# Altium schematic sheet Buzzer.SchDoc
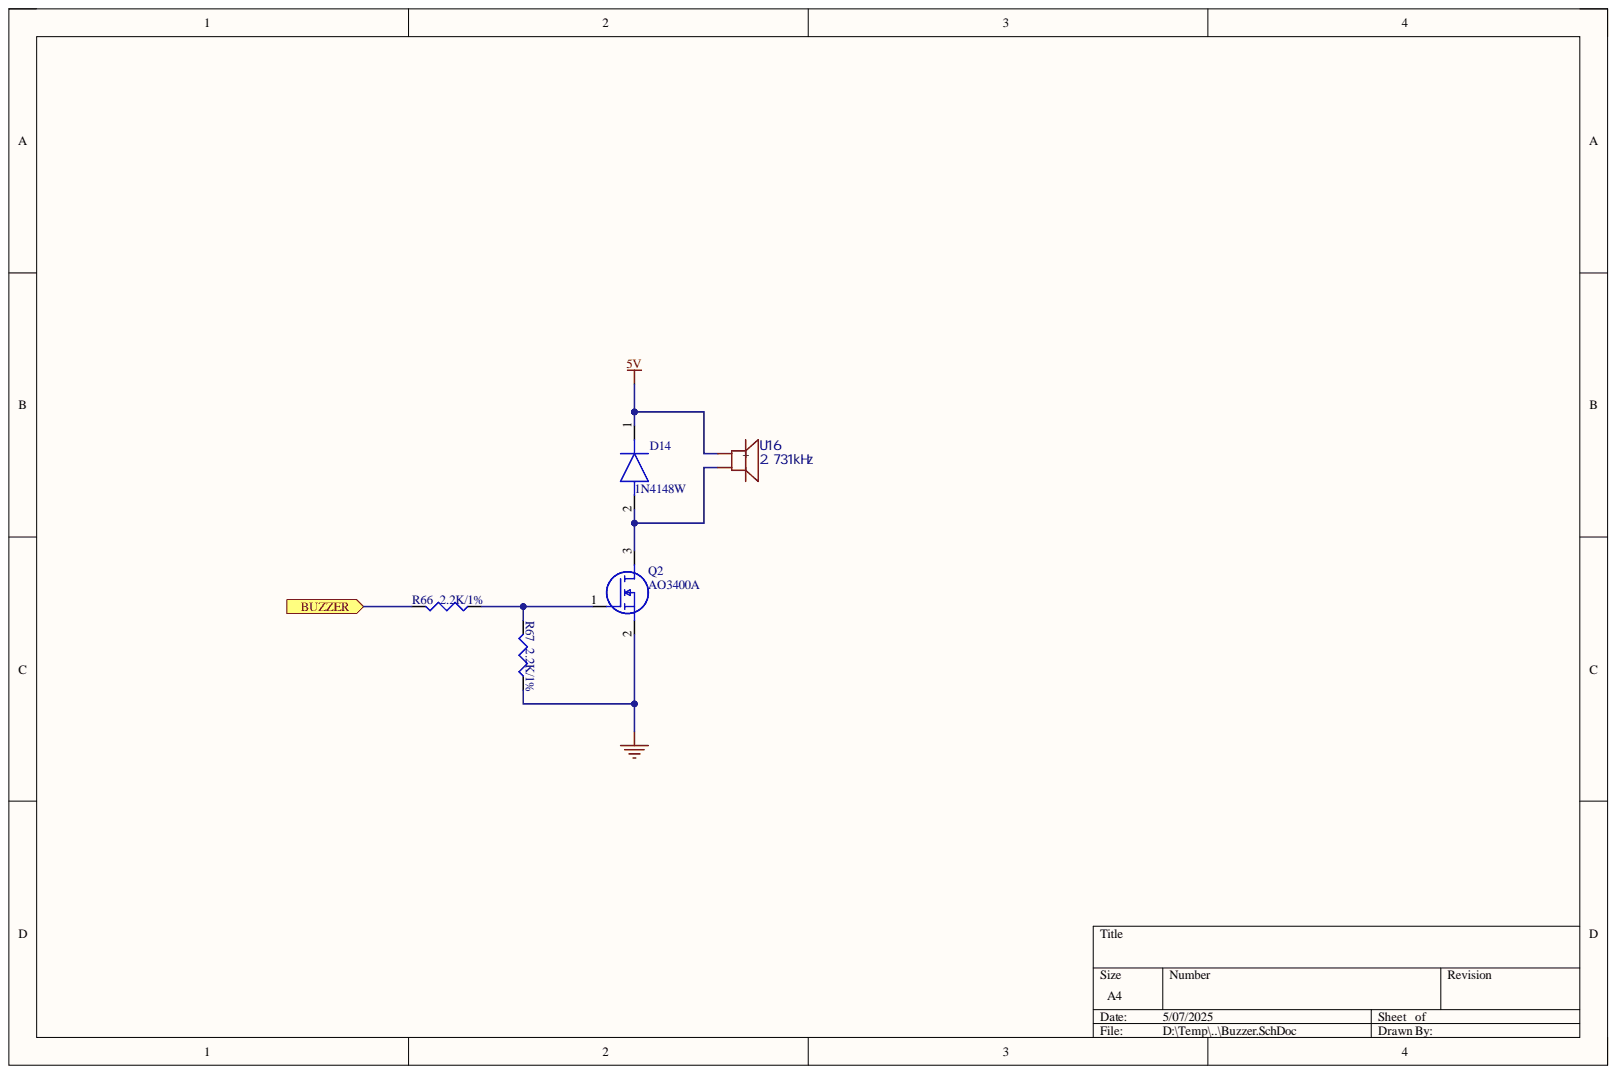
[diagram p0: Buzzer.SchDoc]

## Parts
D14  1N4148W  [1N4148WVGS08]
Q2  AO3400A  [SOT95P280X125-3N]
R66  2.2K/1%  [R0402]
R67  2.2K/1%  [R0402]
U16  2.731kHz  [BUZ-SMD_4P-L7.5-W7.5-BR]

## Ports
BUZZER

## Nets
5V: D14.1 U16.1
GND: Q2.2 R67.1
BUZZER: R66.2 ; port BUZZER
NetD14_2: D14.2 Q2.3 U16.2
NetQ2_1: Q2.1 R66.1 R67.2
NetU16_3: U16.3
NetU16_4: U16.4(+)

## Library data of the parts
D14 = 1N4148W-V-GS08: Diode; Manufacturer_Name: Vishay; Arrow Part Number: 1N4148W-V-GS08; Arrow Price/Stock: https://www.arrow.com/en/products/1n4148w-v-gs08/vishay; Component Kind: Standard; Datasheet Link: https://us.rs-online.com/m/d/a2789c8794cb0c42ced29f2dd8571f3c.pdf; Height: 1.35mm; Manufacturer_Part_Number: 1N4148W-V-GS08; Mouser Part Number: 625-1N4148W-V; Mouser Price/Stock: https://www.mouser.co.uk/ProductDetail/Vishay-Semiconductors/1N4148W-V-GS08?qs=TNTIDjy6APrS%2Fdgq1RSs3A%3D%3D; Library Name: VESC_DEVICE_Library.SchLib
Q2 = AO3400A: MOSFET (N-Channel); Manufacturer_Name: Alpha & Omega Semiconductors; Arrow Part Number: AO3400A; Arrow Price/Stock: https://www.arrow.com/en/products/ao3400a/alpha-and-omega-semiconductor?utm_currency=USD&region=nac; Component Kind: Standard; Datasheet Link: http://aosmd.com/res/data_sheets/AO3400A.pdf; Height: 1.25mm; Manufacturer_Part_Number: AO3400A; Library Name: VESC_DEVICE_Library.SchLib
R66 R67 = RESISTOR: Component Kind: Standard; PCB Footprint: R0402
U16 = HYG-7525A: Manufacturer: HYDZ(华宇); Add into BOM: yes; Current Consumption: 90mA; Sound Pressure Level(SPL): 85dB; Component Kind: Standard; Convert to PCB: yes; Datasheet: https://atta.szlcsc.com/upload/public/pdf/source/20230825/78098EC44B170663F3151CF5366FAD5D.pdf; Device: HYG-7525A; JLCPCB Part Class: 扩展库; LCSC Part Name: 贴片无源蜂鸣器; Manufacturer Part: HYG-7525A; Supplier: LCSC; Supplier Footprint: SMD,7.5x7.5mm; Supplier Part: C7544812; Symbol: HYG-7525A; Value: 2.731kHz; Library Name: VESC_DEVICE_Library.SchLib
